# Altium schematic sheet USB3_PWR.SchDoc
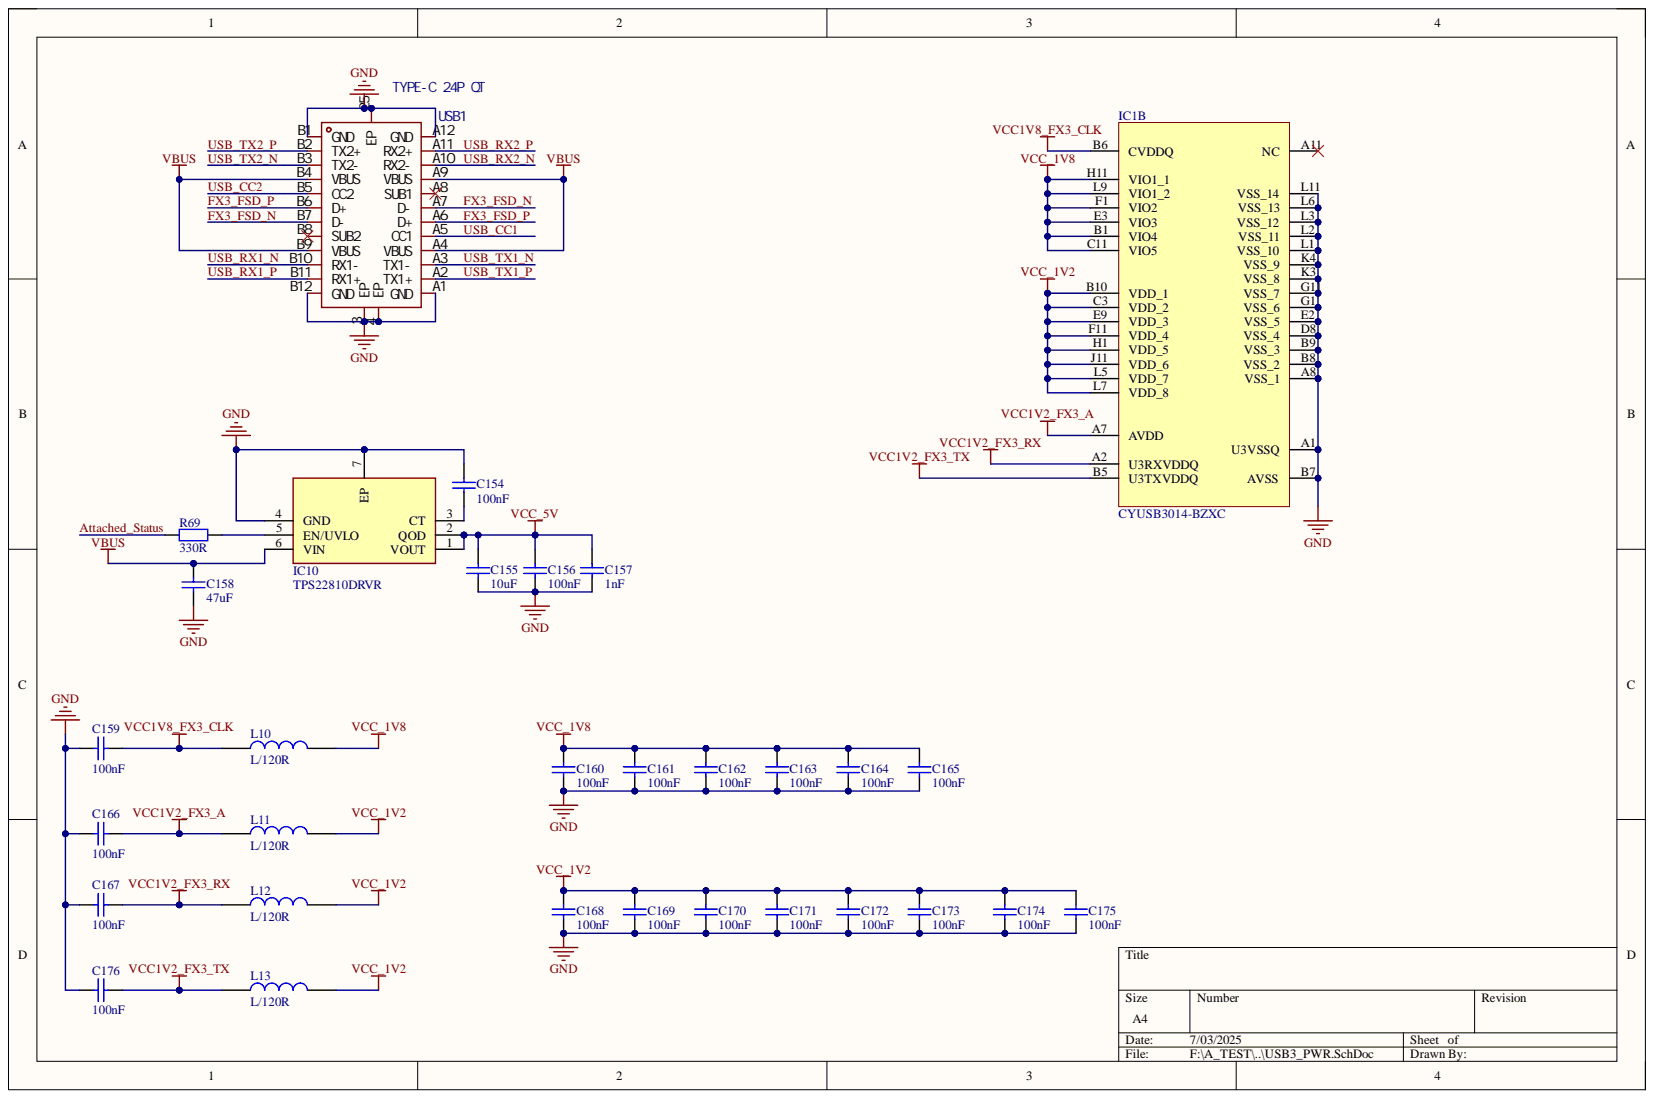
[diagram p0: USB3_PWR.SchDoc]

## Parts
C154  100nF  [C 0402_L]
C155  10uF  [C 0603_L]
C156  100nF  [C 0402_L]
C157  1nF  [C 0402_L]
C158  47uF  [C 0805_L]
C159  100nF  [C 0402_L]
C160  100nF  [C 0402_L]
C161  100nF  [C 0402_L]
C162  100nF  [C 0402_L]
C163  100nF  [C 0402_L]
C164  100nF  [C 0402_L]
C165  100nF  [C 0402_L]
C166  100nF  [C 0402_L]
C167  100nF  [C 0402_L]
C168  100nF  [C 0402_L]
C169  100nF  [C 0402_L]
C170  100nF  [C 0402_L]
C171  100nF  [C 0402_L]
C172  100nF  [C 0402_L]
C173  100nF  [C 0402_L]
C174  100nF  [C 0402_L]
C175  100nF  [C 0402_L]
C176  100nF  [C 0402_L]
IC1  CYUSB3014-BZXC  [BGA121C80P11X11_1000X1000X120]  (part B)
IC10  TPS22810DRVR  [SON65P200X200X80-7N]
L10  L/120R  [L 0402]
L11  L/120R  [L 0402]
L12  L/120R  [L 0402]
L13  L/120R  [L 0402]
R69  330R  [R 0402_L]
USB1  TYPE-C 24P QT  Number of Contacts: 24P  [USB-C-SMD_TYPE-C-24P-QT]

## Nets
GND: C154.2 C155.1 C156.1 C157.1 C158.1 C159.2 C160.1 C161.1 C162.1 C163.1 C164.1 C165.1 C166.2 C167.2 C168.1 C169.1 C170.1 C171.1 C172.1 C173.1 C174.1 C175.1 C176.2 IC1.A1(U3VSSQ) IC1.A8(VSS_1) IC1.B7(AVSS) IC1.B8(VSS_2) IC1.B9(VSS_3) IC1.D8(VSS_4) IC1.E2(VSS_5) IC1.G1(VSS_6) IC1.G11(VSS_7) IC1.K3(VSS_8) IC1.K4(VSS_9) IC1.L1(VSS_10) IC1.L2(VSS_11) IC1.L3(VSS_12) IC1.L6(VSS_13) IC1.L11(VSS_14) IC10.4(GND) IC10.7(EP) USB1.3(EP) USB1.4(EP) USB1.25(EP) USB1.A1(GND) USB1.A12(GND) USB1.B1(GND) USB1.B12(GND)
VBUS: C158.2 IC10.6(VIN) USB1.A4(VBUS) USB1.A9(VBUS) USB1.B4(VBUS) USB1.B9(VBUS)
VCC1V2_FX3_A: C166.1 IC1.A7(AVDD) L11.1
VCC1V2_FX3_RX: C167.1 IC1.A2(U3RXVDDQ) L12.1
VCC1V2_FX3_TX: C176.1 IC1.B5(U3TXVDDQ) L13.1
VCC1V8_FX3_CLK: C159.1 IC1.B6(CVDDQ) L10.1
VCC_1V2: C168.2 C169.2 C170.2 C171.2 C172.2 C173.2 C174.2 C175.2 IC1.B10(VDD_1) IC1.C3(VDD_2) IC1.E9(VDD_3) IC1.F11(VDD_4) IC1.H1(VDD_5) IC1.J11(VDD_6) IC1.L5(VDD_7) IC1.L7(VDD_8) L11.2 L12.2 L13.2
VCC_1V8: C160.2 C161.2 C162.2 C163.2 C164.2 C165.2 IC1.B1(VIO4) IC1.C11(VIO5) IC1.E3(VIO3) IC1.F1(VIO2) IC1.H11(VIO1_1) IC1.L9(VIO1_2) L10.2
VCC_5V: C155.2 C156.2 C157.2 IC10.1(VOUT) IC10.2(QOD)
Attached_Status: R69.1
FX3_FSD_N: USB1.A7(D-) USB1.B7(D-)
FX3_FSD_P: USB1.A6(D+) USB1.B6(D+)
USB_CC1: USB1.A5(CC1)
USB_CC2: USB1.B5(CC2)
USB_RX1_N: USB1.B10(RX1-)
USB_RX1_P: USB1.B11(RX1+)
USB_RX2_N: USB1.A10(RX2-)
USB_RX2_P: USB1.A11(RX2+)
USB_TX1_N: USB1.A3(TX1-)
USB_TX1_P: USB1.A2(TX1+)
USB_TX2_N: USB1.B3(TX2-)
USB_TX2_P: USB1.B2(TX2+)
NetC154_1: C154.1 IC10.3(CT)
NetIC10_5: IC10.5(EN/UVLO) R69.2

Not connected: IC1 A11(NC); USB1 A8(SUB1) B8(SUB2)

## Library data of the parts
C154-C176 = Cap: 贴片电容; Component Kind: Standard; Library Name: A_Schlib.SchLib
  C154 C156 C159-C176: value: 100nF
  C155: value: 10uF
  C157: value: 1nF
  C158: value: 47uF
IC1 = CYUSB3014-BZXC: Integrated Circuit; Manufacturer_Name: Infineon; Arrow Part Number: CYUSB3014-BZXC; Arrow Price/Stock: https://www.arrow.com/en/products/cyusb3014-bzxc/infineon-technologies-ag?utm_currency=USD&region=nac; Component Kind: Standard; Datasheet Link: https://www.infineon.com/dgdl/Infineon-CYUSB301X_CYUSB201X_EZ-USB_FX3_SUPERSPEED_USB_CONTROLLER-DataSheet-v01_00-EN.pdf?fileId=8ac78c8c7d0d8da4017d0eca1e7442aa; Height: 1.2mm; Library Name: SamacSys.SchLib; Manufacturer_Part_Number: CYUSB3014-BZXC; Mouser Part Number: 727-CYUSB3014-BZXC; Mouser Price/Stock: https://www.mouser.co.uk/ProductDetail/Infineon-Technologies/CYUSB3014-BZXC?qs=xP2cAaN2A3Wt%252BQariFLwRg%3D%3D
IC10 = TPS22810DRVR: Integrated Circuit; Manufacturer_Name: Texas Instruments; Arrow Part Number: TPS22810DRVR; Arrow Price/Stock: https://www.arrow.com/en/products/tps22810drvr/texas-instruments?region=nac; Component Kind: Standard; Datasheet Link: http://www.ti.com/lit/gpn/tps22810; Height: 0.8mm; Library Name: SamacSys.SchLib; Manufacturer_Part_Number: TPS22810DRVR; Mouser Part Number: 595-TPS22810DRVR; Mouser Price/Stock: https://www.mouser.co.uk/ProductDetail/Texas-Instruments/TPS22810DRVR?qs=5aG0NVq1C4wkfnc1%252B6LezA%3D%3D
L10-L13 = 电感: 包含功率; Component Kind: Standard; Library Name: A_Schlib.SchLib
R69 = Res: 通用; Component Kind: Standard; Library Name: A_Schlib.SchLib; value: 330R
USB1 = TYPE-C 24P QT: Manufacturer: SHOU HAN(首韩); Add into BOM: yes; Connect-Disconnect Life: 1万次; Core Colour: 黑色; Current Rating - Power (Max): 3A; Gender: 母; Number of Ports: 1; Component Kind: Standard; Connector Type: Type-C; Convert to PCB: yes; Datasheet: https://atta.szlcsc.com/upload/public/pdf/source/20210122/C2681555_B75587FEA5DEA09BB26723DEE30F6A05.pdf; Device: TYPE-C 24P QT; JLCPCB Part Class: 扩展库; LCSC Part Name: Type-C 母 卧贴; Library Name: SamacSys.SchLib; Manufacturer Part: TYPE-C 24P QT; Mounting Style: 卧贴; Operating Temperature Range: -30℃~+80℃; Standard: USB 3.1; Supplier: LCSC; Supplier Footprint: SMD; Supplier Part: C2681555; Symbol: TYPE-C 24P QT
